# Altium schematic sheet DriverControls_PeripheralMain.SchDoc(DriverControls_PeripheralMain)
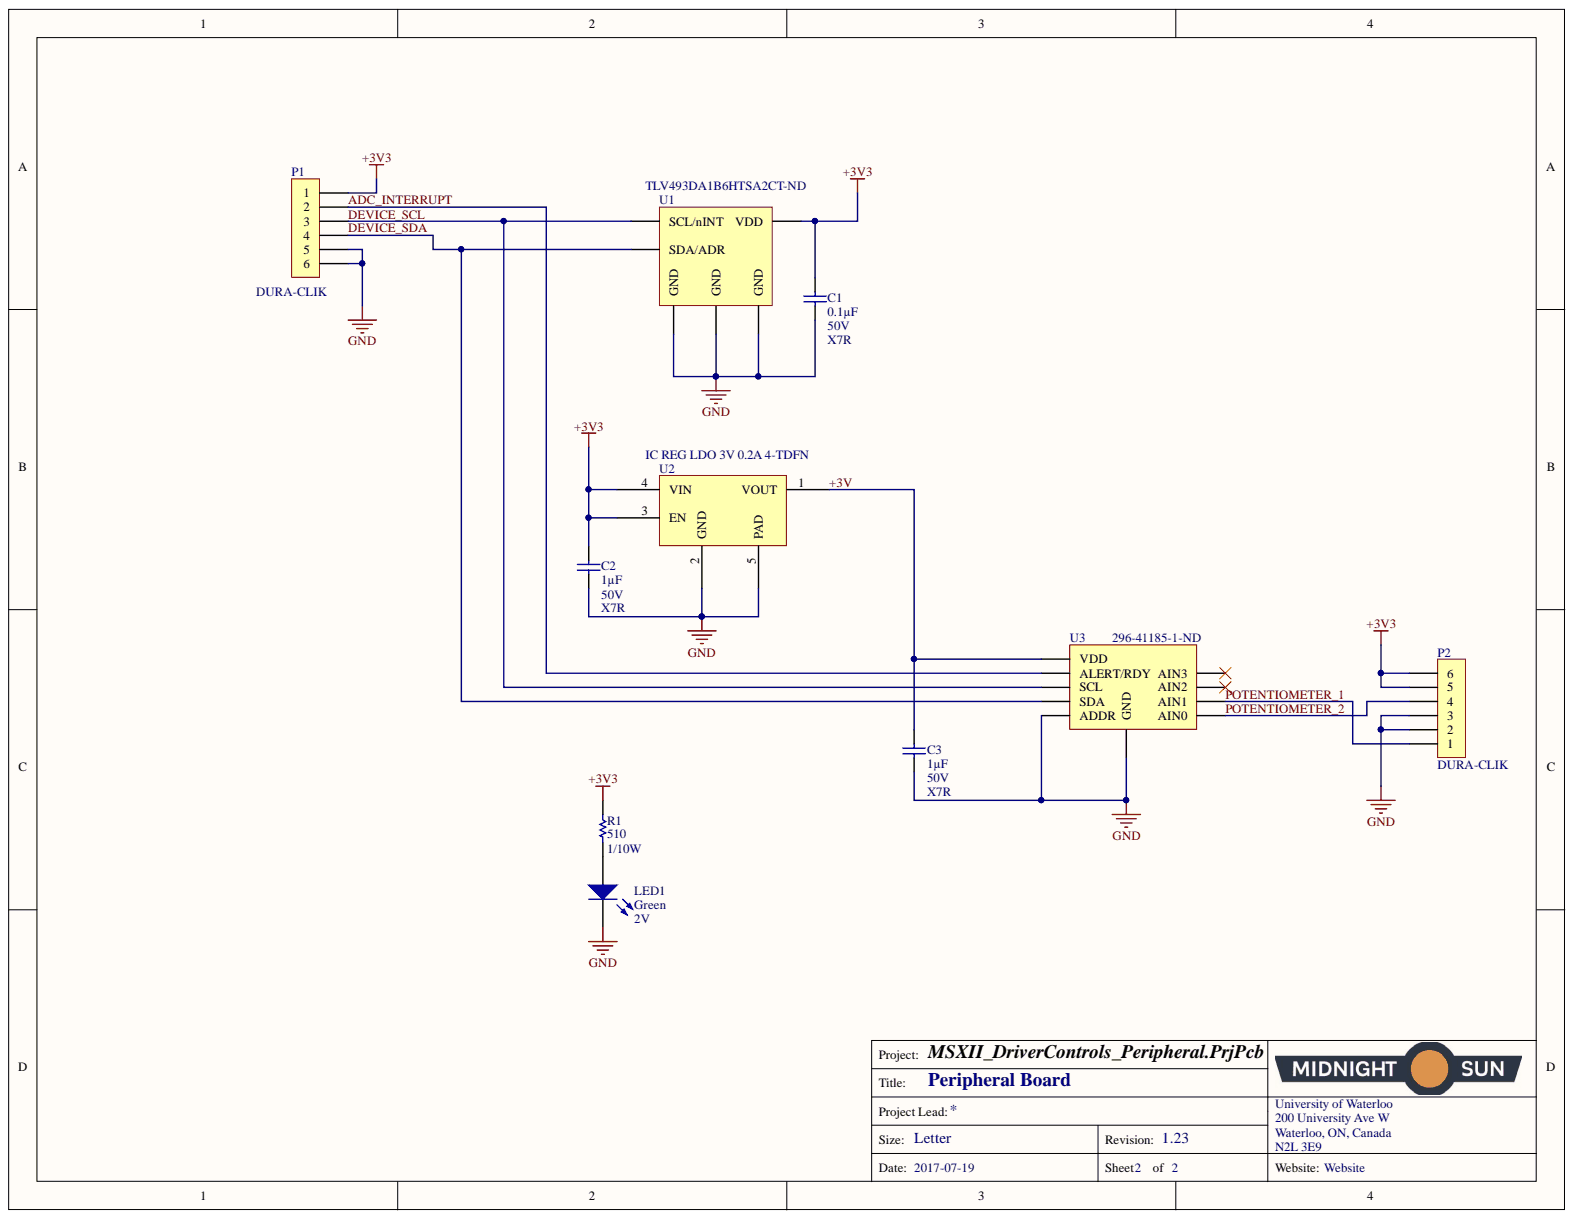
[diagram p0: DriverControls_PeripheralMain.SchDoc]

## Parts
C1  Voltage: 50V  Temperature Coefficient: X7R  Capacitance: 0.1µF  [CAP, 0603]  (hidden comment: CAP CER 0.1UF 50V 10% X7R 0603)
C2  Voltage: 50V  Temperature Coefficient: X7R  Capacitance: 1µF  [CAP, 0603]  (hidden comment: CAP CER 1UF 50V 10% X7R 0603)
C3  Voltage: 50V  Temperature Coefficient: X7R  Capacitance: 1µF  [CAP, 0603]  (hidden comment: CAP CER 1UF 50V 10% X7R 0603)
LED1  Voltage - Forward (Vf) (Typ): 2V  Color: Green  [LED_0603]  (hidden comment: LED GREEN CLEAR 2V 0603)
P1  DURA-CLIK  [CONN, 6POS DURA-CLIK VERT]
P2  DURA-CLIK  [CONN, 6POS DURA-CLIK VERT]
R1  Power: 1/10W  [RES, 0603]  (hidden comment: RES 510 OHM 5% 1/10W 0603)
U1  Supplier Part Number 1: TLV493DA1B6HTSA2CT-ND  [IC TLV496D MAG SENSOR TSOP-6]  (hidden comment: HALL EFFECT)
U2  IC REG LDO 3V 0.2A 4-TDFN  Voltage - Output: 3V  [IC REG LDO 3V 0.2A 4-TDFN]
U3  Supplier Part Number 1: 296-41185-1-ND  [IC ADC VSSOP10]  (hidden comment: Quad ADC)

## Nets
+3V3: C1.1 C2.1 P1.1 P2.5 P2.6 R1.1 U1.4(VDD) U2.3(EN) U2.4(VIN)
GND: C1.2 C2.2 C3.2 LED1.2 P1.5 P1.6 P2.2 P2.3 U1.2(GND) U1.3(GND) U1.5(GND) U2.2(GND) U2.5(PAD) U3.1(ADDR) U3.3(GND)
+3V: C3.1 U2.1(VOUT) U3.8(VDD)
ADC_INTERRUPT: P1.2 U3.2(ALERT/RDY)
DEVICE_SCL: P1.3 U1.1(SCL/nINT) U3.10(SCL)
DEVICE_SDA: P1.4 U1.6(SDA/ADR) U3.9(SDA)
POTENTIOMETER_1: P2.1 U3.5(AIN1)
POTENTIOMETER_2: P2.4 U3.4(AIN0)
NetLED1_1: LED1.1 R1.2

Not connected: U3 6(AIN2) 7(AIN3)

## Library data of the parts
C1 = CAP CER 0.1UF 50V 10% X7R 0603: 0.10µF ±10% 50V Ceramic Capacitor X7R 0603 (1608 Metric); Manufacturer Part Number 1: 06035C104KAT2A; Manufacturer 1: AVX Corporation; Tolerance: ±10%; Thickness (Max): 0.035" (0.90mm); Supplier Part Number 1: 478-5052-1-ND; Supplier 1: Digi-Key; Size / Dimension: 0.063" L x 0.032" W (1.60mm x 0.81mm); Ratings: -; Part Status: Active; Packaging: Cut Tape (CT); Package / Case: 0603 (1608 Metric); Operating Temperature: -55°C ~ 125°C; Mounting Type: Surface Mount, MLCC; Lead Style: -; Lead Spacing: -; Height - Seated (Max): -; ComponentLink1URL: http://datasheets.avx.com/X7RDielectric.pdf; ComponentLink1Description: http://datasheets.avx.com/X7RDielectric.pdf; Component Kind: Standard; Library Name: Schematic Diagrams.SchLib
C2 C3 = CAP CER 1UF 50V 10% X7R 0603: 1µF ±10% 50V Ceramic Capacitor X7R 0603 (1608 Metric); Manufacturer Part Number 1: UMK107AB7105KA-T; Manufacturer 1: Taiyo Yuden; Tolerance: ±10%; Thickness (Max): 0.037" (0.95mm); Supplier Part Number 1: 587-3247-1-ND; Supplier 1: Digi-Key; Size / Dimension: 0.063" L x 0.031" W (1.60mm x 0.80mm); Ratings: -; Part Status: Active; Packaging: Cut Tape (CT); Package / Case: 0603 (1608 Metric); Operating Temperature: -55°C ~ 125°C; Mounting Type: Surface Mount, MLCC; Lead Style: -; Lead Spacing: -; Height - Seated (Max): -; ComponentLink1URL: http://www.yuden.co.jp/productdata/catalog/en/mlcc_all_e.pdf; ComponentLink1Description: http://www.yuden.co.jp/productdata/catalog/en/mlcc_all_e.pdf; Component Kind: Standard; Library Name: Schematic Diagrams.SchLib
LED1 = LED GREEN CLEAR 2V 0603: Green 572nm LED Indication - Discrete 2V 0603 (1608 Metric); Manufacturer Part Number: 150060VS75000; Manufacturer: Wurth Electronics Inc.; Wavelength - Dominant: 570nm; Viewing Angle: 140°; Millicandela Rating: 40mcd; Lens Style/Size: Rectangle with Flat Top, 1.10mm x 0.70mm; Lens Color: Colorless; Current - Test: 20mA; Wavelength - Peak: 572nm; Supplier Part Number 1: 732-4980-1-ND; Supplier Device Package: 0603; Supplier 1: Digi-Key; Stock 1: 9408; Size / Dimension: 1.60mm L x 0.80mm W; RoHS: RoHS Compliant; Pricing 1: 1=0.21, 100=0.1617, 500=0.13964 (CAD); Part Status: Active; Packaging: Cut Tape (CT); Package / Case: 0603 (1608 Metric); Mounting Type: Surface Mount; Lens Transparency: Clear; Height (Max): 0.80mm; Features: -; ComponentLink1URL: http://katalog.we-online.de/led/datasheet/150060VS75000.pdf; ComponentLink1Description: http://katalog.we-online.de/led/datasheet/150060VS75000.pdf; Component Kind: Standard; Category: Optoelectronics; Library Name: Schematic Diagrams.SchLib
P1 P2 = CONN 6POS DURA-CLIK 0.079": 6 Positions Header, Shrouded Connector 0.079" (2.00mm) Surface Mount, Vertical Tin; Manufacturer Part Number: 5600200820; Manufacturer: Molex; Style: Board to Cable/Wire; Shrouding: Shrouded - 4 Wall; Number of Positions Loaded: All; Number of Positions: 6; Mated Stacking Heights: 9.3mm; Voltage Rating: -; Termination: Solder; Supplier Part Number 1: WM10866CT-ND; Supplier 1: Digi-Key; Stock 1: 3826; Row Spacing - Mating: -; RoHS: RoHS Compliant; Pricing 1: 1=1.76, 10=1.542, 25=1.4512, 50=1.391, 100=1.3305, 250=1.20956 (CAD); Pitch - Mating: 0.079" (2.00mm); Part Status: Active; Packaging: Cut Tape (CT); Overall Contact Length: -; Operating Temperature: -; Number of Rows: 1; Mounting Type: Surface Mount; Material Flammability Rating: -; Insulation Material: Polyamide (PA), Nylon; Insulation Height: 0.315" (8.00mm); Insulation Color: Natural; Ingress Protection: -; Features: Solder Retention; Fastening Type: Latch Lock; Current Rating: -; Contact Type: Male Pin; Contact Shape: Square; Contact Material: Copper Alloy; Contact Length - Post: -; Contact Length - Mating: -; Contact Finish Thickness - Post: -; Contact Finish Thickness - Mating: -; Contact Finish - Post: Tin; Contact Finish - Mating: Tin; Connector Type: Header; ComponentLink1URL: http://www.molex.com/pdm_docs/sd/5600200220_sd.pdf; ComponentLink1Description: http://www.molex.com/pdm_docs/sd/5600200220_sd.pdf; Component Kind: Standard; Category: Connectors, Interconnects; Applications: Automotive, Industrial; Library Name: Schematic Diagrams.SchLib
R1 = RES 510 OHM 5% 1/10W 0603: RES SMD 510 OHM 5% 1/10W 0603; Manufacturer Part Number 1: RC0603JR-07510RL; Manufacturer 1: Yageo; Temperature Coefficient: ±100ppm/°C; Resistance: 510; Tolerance: ±5%; Supplier Part Number 1: 311-510GRCT-ND; Supplier Device Package: 0603; Supplier 1: Digi-Key; Size / Dimension: 0.063" L x 0.031" W (1.60mm x 0.80mm); Part Status: Active; Packaging: Cut Tape (CT); Package / Case: 0603 (1608 Metric); Operating Temperature: -55°C ~ 155°C; Height - Seated (Max): 0.022" (0.55mm); Failure Rate: -; Composition: Thick Film; ComponentLink1URL: http://www.yageo.com/documents/recent/PYu-RC_Group_51_RoHS_L_7.pdf; ComponentLink1Description: http://www.yageo.com/documents/recent/PYu-RC_Group_51_RoHS_L_7.pdf; Component Kind: Standard; Library Name: Schematic Diagrams.SchLib
U1 = IC SENSOR HALL I2C TSOP-6: Hall Effect Sensor Linear, Rotary Position External Magnet Not Included SMD (SMT) Tab; Manufacturer Part Number: TLV493DA1B6HTSA2; Manufacturer: Infineon Technologies; Voltage - Supply: 2.7 V ~ 3.5 V; Rotation Angle - Electrical, Mechanical: 0° ~ 360°, Continuous; Output: I²C; Termination Style: SMD (SMT) Tab; Technology: Hall Effect; Supplier 1: Digi-Key; Stock 1: 8466; RoHS: RoHS Compliant; Resistance Tolerance: -; Resistance (Ohms): -; Pricing 1: 1=2.75, 5=2.654, 10=2.155, 25=1.8564, 50=1.608, 100=1.4587, 500=1.22668, 1000=1.09407 (CAD); Part Status: Active; Output Signal: -; Operating Temperature: -40°C ~ 125°C; Mounting Type: Surface Mount; Linear Range: -; Linearity: -; For Measuring: Linear, Rotary Position; ComponentLink1URL: http://www.infineon.com/dgdl/Infineon-TLV493D-A1B6-DS-v01_00-EN.pdf?fileId=5546d462525dbac40152a6b85c760e80; ComponentLink1Description: http://www.infineon.com/dgdl/Infineon-TLV493D-A1B6-DS-v01_00-EN.pdf?fileId=5546d462525dbac40152a6b85c760e80; Component Kind: Standard; Category: Sensors, Transducers; Actuator Type: External Magnet Not Included; Library Name: Schematic Diagrams.SchLib
U2 = IC REG LDO 3V 0.2A 4-TDFN: Manufacturer Part Number: MIC94310-PYMT-TR; Manufacturer: Microchip Technology; Voltage - Input: Up to 3.6V; Voltage - Dropout (Typical): 0.04V @ 200mA; Regulator Topology: Positive Fixed; Current - Output: 200mA; Current - Limit (Min): 250mA; Supplier Part Number 1: 576-4761-1-ND; Supplier Device Package: 4-TDFN (1.2x1.6); Supplier 1: Digi-Key; Stock 1: 4802; RoHS: RoHS Compliant; Pricing 1: 1=0.45, 25=0.3708, 100=0.3386 (CAD); Part Status: Active; Packaging: Cut Tape (CT); Package / Case: 4-UFDFN Exposed Pad; Operating Temperature: -40°C ~ 125°C; Mounting Type: Surface Mount; ComponentLink1URL: http://www.microchip.com/mymicrochip/filehandler.aspx?ddocname=en580409; ComponentLink1Description: http://www.microchip.com/mymicrochip/filehandler.aspx?ddocname=en580409; Component Kind: Standard; Category: Integrated Circuits (ICs); Library Name: Schematic Diagrams.SchLib
U3 = IC ADC 12-BIT VSSOP-10: 12 Bit Analog to Digital Converter 4 Input 1 Sigma-Delta 10-VSSOP; Manufacturer Part Number: ADS1015IDGSR; Manufacturer: Texas Instruments; Voltage - Supply, Digital: 2 V ~ 5.5 V; Sampling Rate (Per Second): 3.3k; Reference Type: Internal; Number of Inputs: 2, 4; Number of Bits: 12; Number of A/D Converters: 1; Input Type: Differential, Single Ended; Data Interface: I²C; Configuration: MUX-PGA-ADC; Voltage - Supply, Analog: 2 V ~ 5.5 V; Supplier Device Package: 10-VSSOP; Supplier 1: Digi-Key; Stock 1: 4084; RoHS: RoHS Compliant; Ratio - S/H: -; Pricing 1: 1=4.25, 10=3.807, 100=3.1194, 500=2.65552, 1000=2.23959 (CAD); Part Status: Active; Packaging: Cut Tape (CT); Package / Case: 10-TFSOP, 10-MSOP (0.118", 3.00mm Width); Operating Temperature: -40°C ~ 125°C; Features: PGA, Selectable Address; ComponentLink1URL: http://www.ti.com/lit/ds/symlink/ads1013.pdf; ComponentLink1Description: http://www.ti.com/lit/ds/symlink/ads1013.pdf; Component Kind: Standard; Category: Integrated Circuits (ICs); Architecture: Sigma-Delta; Library Name: Schematic Diagrams.SchLib
